# Altium schematic sheet comms.SchDoc(comms)
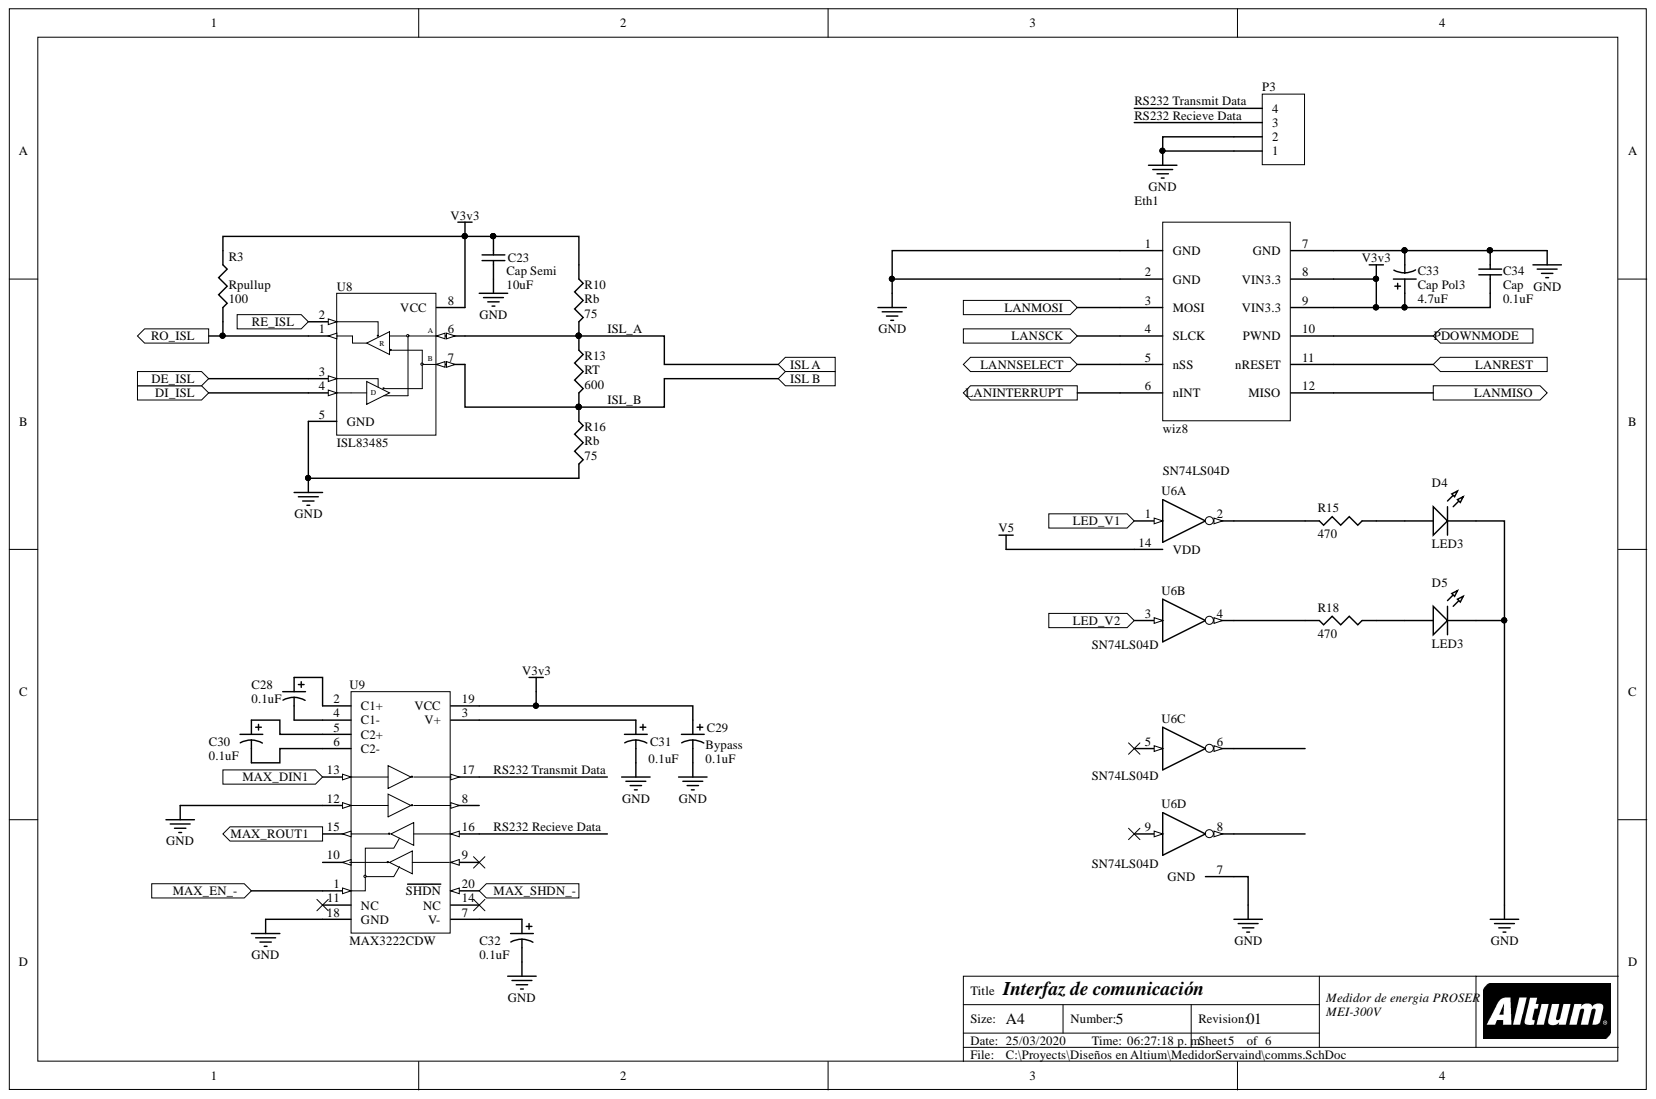
[diagram p0: comms.SchDoc]

## Parts
C23  Cap Semi  value 10uF  [Custom0805]
C28  value 0.1uF  [Custom0805]  (hidden comment: C Pol)
C29  Bypass  value 0.1uF  [Custom0805]
C30  value 0.1uF  [Custom0805]  (hidden comment: C Pol)
C31  value 0.1uF  [Custom0805]  (hidden comment: C Pol)
C32  value 0.1uF  [Custom0805]  (hidden comment: C Pol)
C33  Cap Pol3  value 4.7uF  [Custom0805]
C34  Cap  value 0.1uF  [Custom0805]
D4  LED3  [*0603]
D5  LED3  [*0603]
Eth1  wiz8  [wiz8]
P3  Header 4  [ConectorPrsr]  (value not drawn)
R3  Rpullup  value 100  [Custom0805]
R10  Rb  value 75  [Custom0805]
R13  RT  value 600  [Custom0805]
R15  value 470  [Custom0805]  (hidden comment: Res3)
R16  Rb  value 75  [Custom0805]
R18  value 470  [Custom0805]  (hidden comment: Res3)
U6  SN74LS04D  [751A-03_N]  (parts A,B,C,D)
U8  ISL83485  [SO8_M]
U9  MAX3222CDW  [SOIC20_N]

## Ports
DE_ISL, DI_ISL, ISL A, ISL B, LANINTERRUPT, LANMISO, LANMOSI, LANNSELECT, LANREST, LANSCK, LED_V1, LED_V2, MAX_DIN1, MAX_EN_-, MAX_ROUT1, MAX_SHDN_-, PDOWNMODE, RE_ISL, RO_ISL

## Nets
GND: C23.1 C29.2 C31.2 C32.2 C33.2 C34.2 D4.2 D5.2 Eth1.1(GND) Eth1.2(GND) Eth1.7(GND) P3.1 P3.2 R16.2 U6.7(GND) U8.5(GND) U9.12 U9.18(GND)
V3V3: C23.2 C29.1 C33.1 C34.1 Eth1.8(VIN3.3) Eth1.9(VIN3.3) R3.1 R10.1 U8.8(VCC) U9.19(VCC)
V5: U6.14(VDD)
DE_ISL: U8.3 ; port DE_ISL
DI_ISL: U8.4 ; port DI_ISL
ISL_A: R10.2 R13.1 U8.6 ; port ISL A
ISL_B: R13.2 R16.1 U8.7 ; port ISL B
LANMISO: Eth1.12(MISO) ; port LANMISO
LANMOSI: Eth1.3(MOSI) ; port LANMOSI
LANNSELECT: Eth1.5(nSS) ; port LANNSELECT
LANREST: Eth1.11(nRESET) ; port LANREST
LANSCK: Eth1.4(SLCK) ; port LANSCK
MAX_DIN1: U9.13 ; port MAX_DIN1
MAX_EN_-: U9.1 ; port MAX_EN_-
MAX_ROUT1: U9.15 ; port MAX_ROUT1
MAX_SHDN_-: U9.20(SHDN) ; port MAX_SHDN_-
RE_ISL: U8.2 ; port RE_ISL
RO_ISL: R3.2 U8.1 ; port RO_ISL
RS232 RECIEVE DATA: P3.3 U9.16
RS232 TRANSMIT DATA: P3.4 U9.17
NetC28_1: C28.1 U9.2(C1+)
NetC28_2: C28.2 U9.4(C1-)
NetC30_1: C30.1 U9.5(C2+)
NetC30_2: C30.2 U9.6(C2-)
NetC31_1: C31.1 U9.3(V+)
NetC32_1: C32.1 U9.7(V-)
NetD4_1: D4.1 R15.1
NetD5_1: D5.1 R18.1
NetEth1_6: Eth1.6(nINT) ; port LANINTERRUPT
NetEth1_10: Eth1.10(PWND) ; port PDOWNMODE
NetR15_2: R15.2 U6.2
NetR18_2: R18.2 U6.4
NetU6_1: U6.1 ; port LED_V1
NetU6_3: U6.3 ; port LED_V2

Not connected: U6 5 6 8 9; U9 8 9 10 11(NC) 14(NC)

## Library data of the parts
C23 = Cap Semi: Capacitor (Semiconductor SIM Model); Component Kind: Standard; LatestRevisionDate: 29-May-2009; LatestRevisionNote: IPC-7351 Footprint Added.; Library Name: Miscellaneous Devices.IntLib; PackageDescription: Chip Capacitor; PackageReference: C1206; Published: 8-Jun-2000; Signal Integrity: Cap Semi; Simulation: CAP; Publisher: Altium Limited
C28-C33 = Cap Pol3: Polarized Capacitor (Surface Mount); Component Kind: Standard; LatestRevisionDate: 29-May-2009; LatestRevisionNote: IPC-7351 Footprint Added.; Library Name: Miscellaneous Devices.IntLib; PackageDescription: Chip Capacitor; PackageReference: C0805; Published: 8-Jun-2000; Signal Integrity: Cap Pol; Simulation: CAP; Publisher: Altium Limited
C34 = Cap: Capacitor; Component Kind: Standard; LatestRevisionDate: 17-Jul-2002; LatestRevisionNote: Re-released for DXP Platform.; Library Name: Miscellaneous Devices.IntLib; PackageDescription: Radial Cap, Thru-Hole; 2 Leads; 0.3 in Pin Spacing; PackageReference: RAD-0.3; Published: 8-Jun-2000; Signal Integrity: Cap; Simulation: CAP; Publisher: Altium Limited
D4 D5 = LED3: Typical BLUE SiC LED; Component Kind: Standard; DatasheetVersion: 16-Apr-2002; LatestRevisionDate: 17-Dec-2003; LatestRevisionNote: PCB Footprint replaced.; Library Name: Miscellaneous Devices.IntLib; PackageDescription: SM LED; 2 C-Bend Leads; Body 3.5 x 2.8 x 1.9 mm, inc leads (LxWxH typ); PackageReference: 3.5X2.8X1.9; PackageVersion: 16-Apr-2002; Published: 8-Jun-2000; Signal Integrity: LED; Simulation: LED3; Publisher: Altium Limited
P3 = Header 4: Header, 4-Pin; Component Kind: Standard; LatestRevisionDate: 17-Jul-2002; LatestRevisionNote: Re-released for DXP Platform.; Library Name: Miscellaneous Connectors.IntLib; Signal Integrity: Connector; Publisher: Altium Limited
R3 R10 R13 R15 R16 R18 = Res3: Resistor; Component Kind: Standard; LatestRevisionDate: 29-May-2009; LatestRevisionNote: IPC-7351 Footprint Added.; Library Name: Miscellaneous Devices.IntLib; PackageDescription: Chip Resistor; PackageReference: J1-0603; Published: 8-Jun-2000; Signal Integrity: Res; Simulation: RESISTOR; Publisher: Altium Limited
U6 = SN74LS04D: Hex Inverter; Code_IPC: SOIC127P600-14; Code_JEDEC: MS-012-AB; Component Kind: Standard; ComponentLink1Description: Manufacturer Link; ComponentLink1URL: http://www.onsemi.com/; ComponentLink2Description: Datasheet; ComponentLink2URL: http://www.onsemi.com/pub/Collateral/SN74LS04-D.PDF; DatasheetVersion: 1999; LatestRevisionDate: 13-Apr-2006; LatestRevisionNote: IPC-7351 Footprint Added.; Library Name: ON Semi Logic Buffer Line Driver.IntLib; PackageDescription: 14-Pin Small Outline Integrated Circuit 1.27 mm Pitch; PackageReference: 751A-03; Published: 15-Apr-2002; Signal Integrity: SN74LS04D; Publisher: Altium Limited
U8 = MAX485ESA: Low-Power, Slew-Rate-Limited RS-485/RS-422 Transceiver; Code_IPC: SOIC127P600-8; Code_JEDEC: MS-012-AA; Component Kind: Standard; ComponentLink1Description: Manufacturer Link; ComponentLink1URL: http://www.maxim-ic.com/; ComponentLink2Description: Datasheet; ComponentLink2URL: http://pdfserv.maxim-ic.com/en/ds/MAX1487-MAX491.pdf; DatasheetVersion: Feb-1996; LatestRevisionDate: 13-Apr-2006; LatestRevisionNote: IPC-7351 Footprint Added.; Library Name: Maxim Communication Transceiver.IntLib; PackageDescription: 8-Pin Small Outline Integrated Circuit 1.27 mm Pitch; PackageReference: NSO8; PCB3D: NSO8; Published: 16-Jan-2001; Publisher: Altium Limited
U9 = MAX3222CAP: 3.0V TO 5.5V, Low-Power, up to 1Mbps, True RS-232 Transceiver Using Four 0.1µF External Capacitor; Code_IPC: SOP65P780-20; Code_JEDEC: MO-150-AE; Component Kind: Standard; ComponentLink1Description: Manufacturer Link; ComponentLink1URL: http://www.maxim-ic.com/; ComponentLink2Description: Datasheet; ComponentLink2URL: http://pdfserv.maxim-ic.com/en/ds/MAX3222-MAX3241.pdf; DatasheetVersion: Mar-1999; LatestRevisionDate: 29-May-2009; LatestRevisionNote: IPC-7351 Footprint Added.; Library Name: Maxim Communication Transceiver.IntLib; PackageDescription: 20-Pin Small Outline Package 0.65 mm Pitch; PackageReference: SSOP20; PackageVersion: 1997; PCB3D: SSOP20; Published: 16-Jan-2001; Publisher: Altium Limited
Eth1 = wiz8: Component Kind: Standard; Library Name: wiz8.IntLib
